# Altium schematic sheet SM-1301.SchDoc
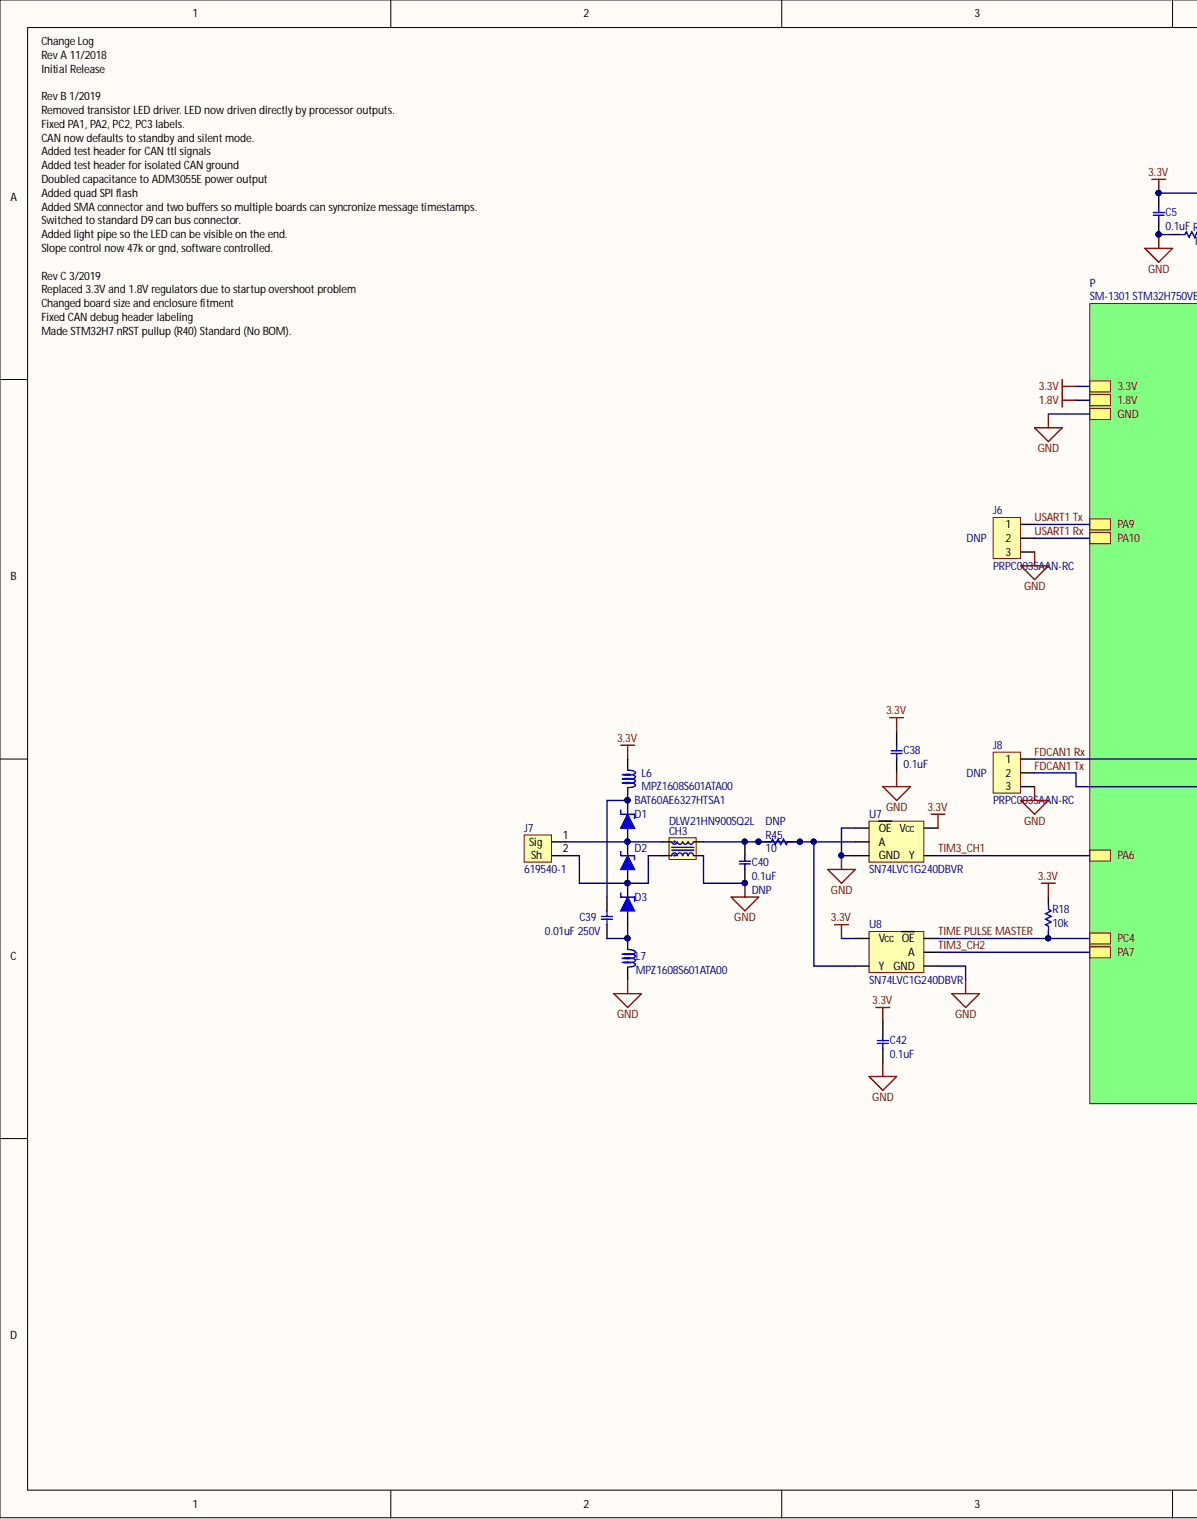
[diagram p0: SM-1301.SchDoc, left]
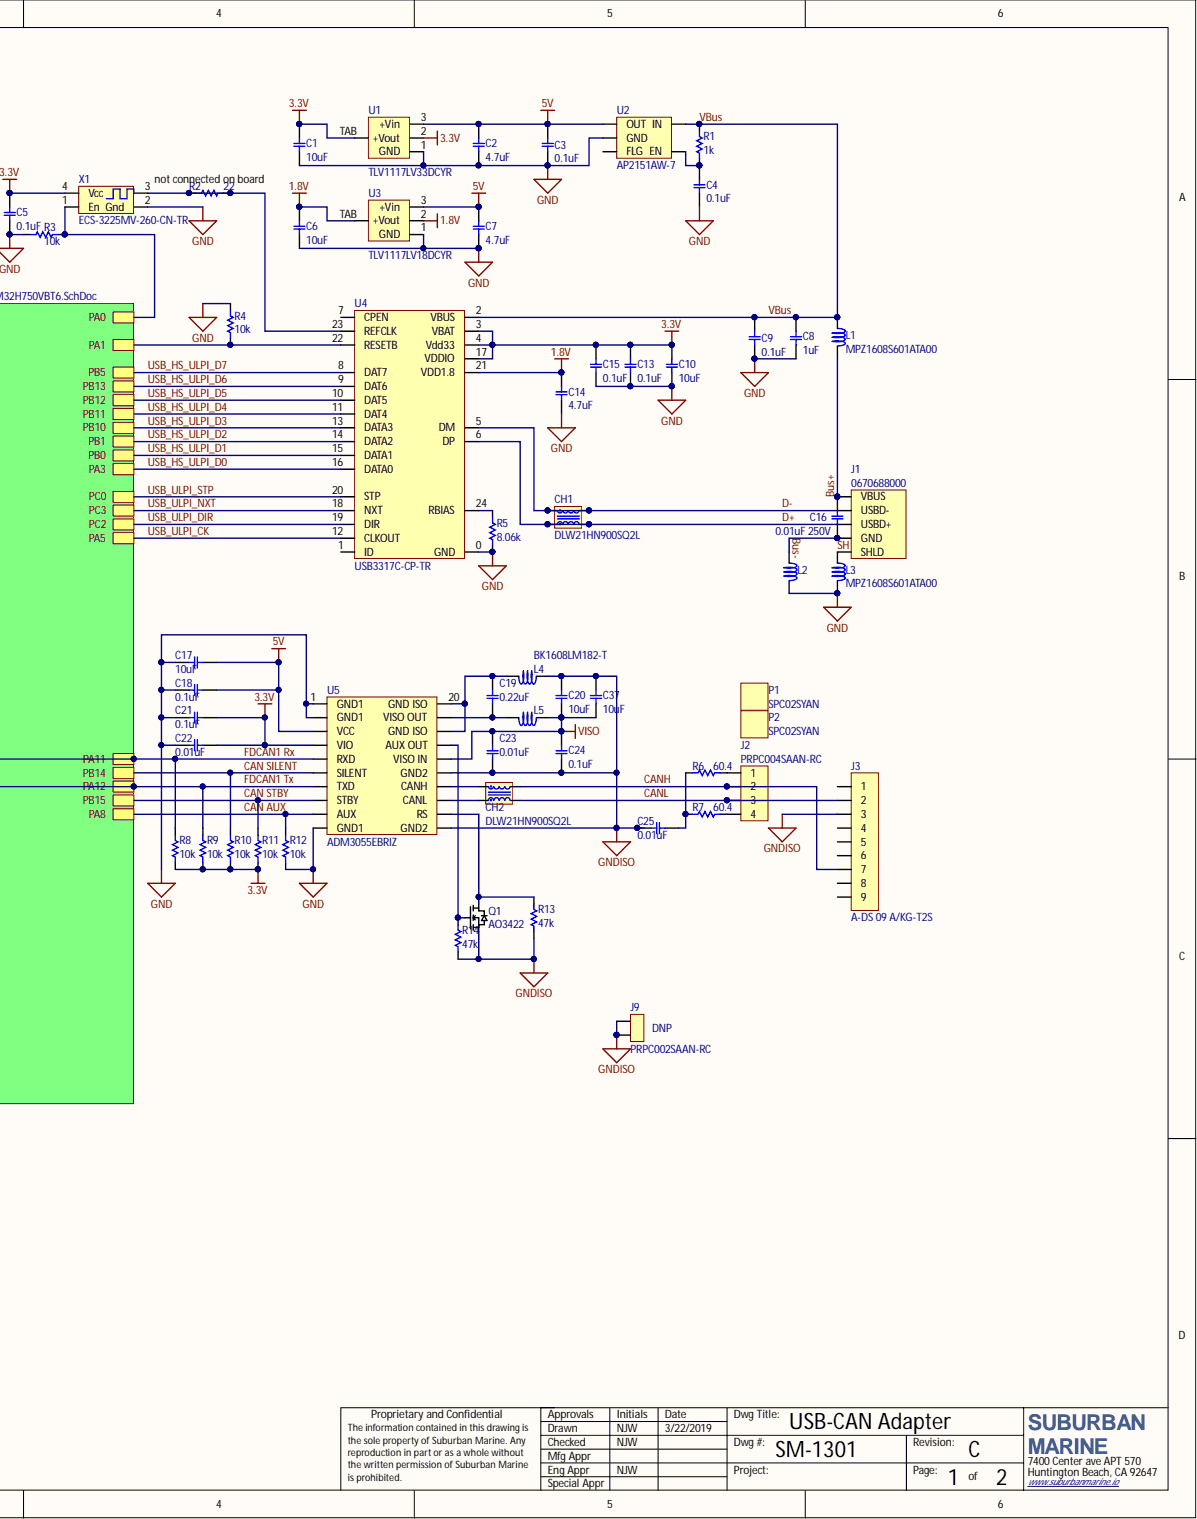
[diagram p1: SM-1301.SchDoc, right]

## Parts
C1  value 10uF  [0805]  (hidden comment: CL21A106KOQNNNG)
C2  value 4.7uF  [0805]  (hidden comment: CL21A475KOFNNNG)
C3  value 0.1uF  [0603]  (hidden comment: CL10B104KO8WPNC)
C4  value 0.1uF  [0603]  (hidden comment: CL10B104KO8WPNC)
C5  value 0.1uF  [0603]  (hidden comment: CL10B104KO8WPNC)
C6  value 10uF  [0805]  (hidden comment: CL21A106KOQNNNG)
C7  value 4.7uF  [0805]  (hidden comment: CL21A475KOFNNNG)
C8  value 1uF  [0603]  (hidden comment: CL10B105MO8NNWC)
C9  value 0.1uF  [0603]  (hidden comment: CL10B104KO8WPNC)
C10  value 10uF  [0805]  (hidden comment: CL21A106KOQNNNG)
C13  value 0.1uF  [0603]  (hidden comment: CL10B104KO8WPNC)
C14  value 4.7uF  [0805]  (hidden comment: CL21A475KOFNNNG)
C15  value 0.1uF  [0603]  (hidden comment: CL10B104KO8WPNC)
C16  value 0.01uF 250V  [0805]  (hidden comment: C0805C103KARACTU)
C17  value 10uF  [0805]  (hidden comment: CL21A106KOQNNNG)
C18  value 0.1uF  [0603]  (hidden comment: CL10B104KO8WPNC)
C19  value 0.22uF  [0805]  (hidden comment: CL21B224KOCNNNC)
C20  value 10uF  [0805]  (hidden comment: CL21A106KOQNNNG)
C21  value 0.1uF  [0603]  (hidden comment: CL10B104KO8WPNC)
C22  value 0.01uF  [0603]  (hidden comment: CL10B103KA8WPNC)
C23  value 0.01uF  [0603]  (hidden comment: CL10B103KA8WPNC)
C24  value 0.1uF  [0603]  (hidden comment: CL10B104KO8WPNC)
C25  value 0.01uF  [0603]  (hidden comment: CL10B103KA8WPNC)
C37  value 10uF  [0805]  (hidden comment: CL21A106KOQNNNG)
C38  value 0.1uF  [0603]  (hidden comment: CL10B104KO8WPNC)
C39  value 0.01uF 250V  [0805]  (hidden comment: C0805C103KARACTU)
C40  value 0.1uF  [0603]  (hidden comment: CL10B104KO8WPNC)  (no BOM)
C42  value 0.1uF  [0603]  (hidden comment: CL10B104KO8WPNC)
CH1  DLW21HN900SQ2L  [ACM2012]  (no BOM)
CH2  DLW21HN900SQ2L  [ACM2012]
CH3  DLW21HN900SQ2L  [ACM2012]  (no BOM)
D1  BAT60AE6327HTSA1  [SOD-323]
D2  BAT60AE6327HTSA1  [SOD-323]
D3  BAT60AE6327HTSA1  [SOD-323]
J1  0670688000  [0670688000]
J2  PRPC004SAAN-RC  [HDR1X4]
J3  A-DS 09 A/KG-T2S  [A-DS 09 A/KG-T2S]
J6  PRPC003SAAN-RC  [HDR1X3]  (no BOM)
J7  619540-1  [619540-1]
J8  PRPC003SAAN-RC  [HDR1X3]  (no BOM)
J9  PRPC002SAAN-RC  [HDR1X2]  (no BOM)
L1  MPZ1608S601ATA00  [0603]
L2  MPZ1608S601ATA00  [0603]
L3  MPZ1608S601ATA00  [0603]
L4  BK1608LM182-T  [0603]
L5  BK1608LM182-T  [0603]
L6  MPZ1608S601ATA00  [0603]
L7  MPZ1608S601ATA00  [0603]
P1  SPC02SYAN  [969102-0000-DA]
P2  SPC02SYAN  [969102-0000-DA]
Q1  AO3422  [SOT-23]
R1  value 1k  [0603]  (hidden comment: RC0603FR-071KL)
R2  value 22  [0603]  (hidden comment: ERJ-3EKF22R0V)  (no BOM)
R3  value 10k  [0603]  (hidden comment: ERJ-3EKF1002V)
R4  value 10k  [0603]  (hidden comment: ERJ-3EKF1002V)
R5  value 8.06k  [0603]  (hidden comment: ERJ-3EKF8061V)
R6  value 60.4  [0603]  (hidden comment: ERJ-3EKF60R4V)
R7  value 60.4  [0603]  (hidden comment: ERJ-3EKF60R4V)
R8  value 10k  [0603]  (hidden comment: ERJ-3EKF1002V)
R9  value 10k  [0603]  (hidden comment: ERJ-3EKF1002V)
R10  value 10k  [0603]  (hidden comment: ERJ-3EKF1002V)
R11  value 10k  [0603]  (hidden comment: ERJ-3EKF1002V)
R12  value 10k  [0603]  (hidden comment: ERJ-3EKF1002V)
R13  value 47k  [0603]  (hidden comment: RC0603FR-0747KL)
R14  value 47k  [0603]  (hidden comment: RC0603FR-0747KL)
R18  value 10k  [0603]  (hidden comment: ERJ-3EKF1002V)
R45  value 10  [0603]  (hidden comment: RC0603FR-0710RL)  (no BOM)
U1  TLV1117LV33DCYR  value 3.3V  [SOT-223]
U2  AP2151AW-7  [sot23-5]
U3  TLV1117LV18DCYR  value 1.8V  [SOT-223]
U4  USB3317C-CP-TR  [qfn-24]
U5  ADM3055EBRIZ  [SOIC-20w]
U7  SN74LVC1G240DBVR  [sot-23-5]
U8  SN74LVC1G240DBVR  [sot-23-5]
X1  ECS-3225MV-260-CN-TR  [3.2x2.5]

## Nets
1.8V: C6.2 C14.2 U3.2 U3.TAB(+Vout) U4.21(VDD1.8)
3.3V: C1.2 C5.1 C10.2 C13.1 C15.1 C21.1 C22.1 C38.2 C42.2 L6.2 R8.2 R9.2 R10.2 R11.2 R18.1 U1.2 U1.TAB(+Vout) U4.3(VBAT) U4.4(Vdd33) U4.17(VDDIO) U5.4(VIO) U7.5(Vcc) U8.5(Vcc) X1.4(Vcc)
5V: C2.2 C3.2 C7.2 C17.2 C18.2 U1.3(+Vin) U2.1(OUT) U3.3(+Vin) U5.3(VCC)
GND: C1.1 C2.1 C3.1 C4.2 C5.2 C6.1 C7.1 C8.1 C9.1 C10.1 C13.2 C14.1 C15.2 C17.1 C18.1 C21.2 C22.2 C38.1 C40.1 C42.1 CH3.3 J6.3 J8.3 L2.1 L3.1 L7.1 R3.2 R4.2 R5.1 R12.2 U1.1(GND) U2.2(GND) U3.1(GND) U4.0(GND) U5.1(GND1) U5.2(GND1) U5.10(GND1) U7.1(OE) U7.3(GND) U8.3(GND) X1.2(Gnd)
GNDISO: C20.2 C23.1 C24.1 C25.2 C37.2 J3.3 J9.1 J9.2 L4.2 Q1.3 R13.2 R14.2 U5.11(GND2) U5.15(GND2)
VISO: C20.1 C23.2 C24.2 C37.1 L5.2 U5.16(VISO_IN)
Bus+: C16.2 J1.1(VBUS) L1.1
Bus-: C16.1 J1.4(GND) L2.2
CAN AUX: R12.1 U5.9(AUX)
CAN SILENT: R10.1 U5.6(SILENT)
CAN STBY: R11.1 U5.8(STBY)
CANH: CH2.4 J2.2 J3.7
CANL: CH2.3 J2.3 J3.2
D+: CH1.2 CH1.3 J1.3(USBD+) U4.6(DP)
D-: CH1.1 CH1.4 J1.2(USBD-) U4.5(DM)
FDCAN1 Rx: J8.1 R8.1 U5.5(RXD)
FDCAN1 Tx: J8.2 R9.1 U5.7(TXD)
SH: J1.0(SHLD) L3.2
TIM3_CH1: U7.4(Y)
TIM3_CH2: U8.2(A)
TIME PULSE MASTER: R18.2 U8.1(OE)
USART1 Rx: J6.2
USART1 Tx: J6.1
USB_HS_ULPI_D0: U4.16(DATA0)
USB_HS_ULPI_D1: U4.15(DATA1)
USB_HS_ULPI_D2: U4.14(DATA2)
USB_HS_ULPI_D3: U4.13(DATA3)
USB_HS_ULPI_D4: U4.11(DAT4)
USB_HS_ULPI_D5: U4.10(DAT5)
USB_HS_ULPI_D6: U4.9(DAT6)
USB_HS_ULPI_D7: U4.8(DAT7)
USB_ULPI_CK: U4.12(CLKOUT)
USB_ULPI_DIR: U4.19(DIR)
USB_ULPI_NXT: U4.18(NXT)
USB_ULPI_STP: U4.20(STP)
VBus: C8.2 C9.2 L1.2 R1.2 U2.5(IN) U4.2(VBUS)
NetC4_1: C4.1 R1.1 U2.4(EN)
NetC19_1: C19.1 L5.1 U5.19(VISO_OUT)
NetC19_2: C19.2 L4.1 U5.18(GND_ISO) U5.20(GND_ISO)
NetC25_1: C25.1 R6.1 R7.1
NetC39_1: C39.1 D3.1 L7.2
NetC39_2: C39.2 D1.2 L6.1
NetC40_2: C40.2 CH3.4 R45.1 R45.2 U7.2(A) U8.4(Y)
NetCH2_1: CH2.1 U5.14(CANH)
NetCH2_2: CH2.2 U5.13(CANL)
NetCH3_1: CH3.1 D1.1 D2.2 J7.1
NetCH3_2: CH3.2 D2.1 D3.2 J7.2
NetJ2_1: J2.1 R6.2
NetJ2_4: J2.4 R7.2
NetJ3_1: J3.1
NetJ3_4: J3.4
NetJ3_5: J3.5
NetJ3_6: J3.6
NetJ3_8: J3.8
NetJ3_9: J3.9
NetQ1_1: Q1.1 R14.1 U5.17(AUX_OUT)
NetQ1_2: Q1.2 R13.1 U5.12(RS)
NetR2_1: R2.1 R2.2 U4.23(REFCLK) X1.3
NetR3_1: R3.1 X1.1(En)
NetR4_1: R4.1 U4.22(RESETB)
NetR5_2: R5.2 U4.24(RBIAS)
NetU2_3: U2.3(FLG)
NetU4_1: U4.1(ID)
NetU4_7: U4.7(CPEN)

## Library data of the parts
C1 C6 C10 C17 C20 C37 = 10uF 16V 0805: CAP CER 10UF 16V X5R 0805; Manufacturer: Samsung Electro-Mechanics; Installation: SMD/SMT; Lead: 0; Vendor: Digikey; Vendor #: 1276-6455-1-ND; Component Kind: Standard; Library Name: Capacitor.SchLib; Manufacturer #: CL21A106KOQNNNG; Price: .26; Temperature: -55 to 85 C
C2 C7 C14 = 4.7uF 25V 0805: CAP CER 4.7UF 16V X5R 0805; Manufacturer: Samsung Electro-Mechanics; Installation: SMD/SMT; Lead: 0; Tolerance: ±10%; Vendor: Digikey; Vendor #: 1276-6463-1-ND; Component Kind: Standard; Library Name: Capacitor.SchLib; Manufacturer #: CL21A475KOFNNNG; Price: 0.2; Temperature: -55 to 125 C
C3-C5 C9 C13 C15 C18 C21 C24 C38 C40 C42 = 0.1uF 16V 0603: CAP CER 0.1UF 16V X7R 0603; Manufacturer: Samsung Electro-Mechanics; Installation: SMD/SMT; Lead: 0; Tolerance: 10%; Vendor: Digikey; Vendor #: 1276-6582-1-ND; Library Name: Capacitor.SchLib; Manufacturer #: CL10B104KO8WPNC; Price: 0.1; Temperature: -55 to 125 C
  C3-C5 C9 C13 C15 C18 C21 C24 C38 C42: Component Kind: Standard
C8 = 1uF 16V 0603: CAP CER 1UF 16V X7R 0603; Manufacturer: Samsung Electro-Mechanics; Installation: SMD/SMT; Lead: 0; Vendor: Digikey; Vendor #: 1276-6524-1-ND; Component Kind: Standard; Library Name: Capacitor.SchLib; Manufacturer #: CL10B105MO8NNWC; Price: 0.10; Temperature: -55 to 125 C; Tolerance: 16V
C16 C39 = 0.01uF 250V 0805: CAP CER 10000PF 250V X7R 0805; Manufacturer: Kemet; Installation: SMD/SMT; Lead: 0; Tolerance: 250v 10%; Vendor: Digikey; Vendor #: 399-4669-1-ND; Component Kind: Standard; Library Name: Capacitor.SchLib; Manufacturer #: C0805C103KARACTU; Price: 0.23; Temperature: -55 to 125 C
C19 = 0.22uF 16V 0805: CAP CER 0.22UF 16V X7R 0805; Manufacturer: Samsung Electro-Mechanics; Installation: SMD/SMT; Lead: 0; Tolerance: 10%; Vendor: Digikey; Vendor #: 1276-1284-1-ND; Component Kind: Standard; Library Name: Capacitor.SchLib; Manufacturer #: CL21B224KOCNNNC; Price: .13; Temperature: -55 to 125 C
C22 C23 C25 = 0.01uF 25V 0603: CAP CER 10000PF 25V X7R 0603; Manufacturer: Samsung Electro-Mechanics; Installation: SMD/SMT; Lead: 0; Tolerance: 10%; Vendor: Digikey; Vendor #: 1276-6531-1-ND; Component Kind: Standard; Library Name: Capacitor.SchLib; Manufacturer #: CL10B103KA8WPNC; Price: .13; Temperature: -55 to 125 C
CH1-CH3 = DLW21HN900SQ2L: CMC 330MA 2LN 90 OHM SMD; Manufacturer: Murata Electronics North America; Installation: SMD/SMT; Lead: 0; Value: 90Ω@100MHz; Vendor: Digikey; Vendor #: 490-1064-1-ND; Library Name: Inductor.SchLib; Manufacturer #: DLW21HN900SQ2L; Price: 0.53; Temperature: -40 to 85 C
  CH2: Component Kind: Standard
D1-D3 = BAT60AE6327HTSA1: DIODE SCHOTTKY 10V 3A SOD-323; Manufacturer: Infineon Technologies; Installation: SMD/SMT; Lead: 0; Vendor: Digikey; Vendor #: BAT60AE6327HTSA1CT-ND; Component Kind: Standard; Library Name: Diode.SchLib; Manufacturer #: BAT60AE6327HTSA1; Price: 0.5; Temperature: -55 to 125 C
J1 = 0670688000: CONN USB RT ANG RECPT TYPE B BLK; Manufacturer: Molex, LLC; Installation: SMD/SMT; Lead: 0; Vendor: Digikey; Vendor #: WM17113-ND; Component Kind: Standard; Library Name: Connectors.SchLib; Manufacturer #: 0670688000; Price: 1.53; Temperature: 0 to 50 C
J2 = Header 1x4: CONN HEADER 4 POS .100" SGL GOLD; Manufacturer: Sullins Connector Solutions; Installation: Thru-hole; Lead: 0; Value: 4 pos; Vendor: Digikey; Vendor #: S1011EC-04-ND; Component Kind: Standard; Library Name: Headers.SchLib; Manufacturer #: PRPC004SAAN-RC; PCB3D: HDR1X4; Price: 0.74; Temperature: -40 to 105 C
J3 = A-DS 09 A/KG-T2S: CONN D-SUB PLUG 9POS R/A SOLDER; Manufacturer: Assmann WSW Components; Installation: Thru-hole; Lead: 0; Vendor: Digikey; Vendor #: AE10968-ND; Component Kind: Standard; Library Name: Connectors.SchLib; Manufacturer #: A-DS 09 A/KG-T2S; Price: 0.77; Temperature: -55 to 105 C; Value: 9 pos
J6 J8 = Header 1x3: CONN HEADER .100" SNGL STR 3POS; Manufacturer: Sullins Connector Solutions; Installation: Thru-hole; Lead: 0; Vendor: Digikey; Vendor #: S1011EC-03-ND; Library Name: Headers.SchLib; Manufacturer #: PRPC003SAAN-RC; Price: 0.12; Temperature: -40 to 105 C; Value: 3 pos
J7 = 619540-1: CONN SMA JACK R/A 50 OHM PCB; Manufacturer: TE Connectivity AMP Connectors; Manufacturer #: A120734-ND; Installation: Thru-hole; Lead: 0; Vendor: Digikey; Component Kind: Standard; Library Name: Connectors.SchLib; Price: 3.67; Temperature: -65 to 85 C; Vendor #: A120734-ND
J9 = Header 1x2: CONN HEADER .100" SNGL STR 2POS; Manufacturer: Sullins Connector Solutions; Installation: Thru-hole; Lead: 0; Vendor: Digikey; Vendor #: S1011EC-02-ND; Library Name: Headers.SchLib; Manufacturer #: PRPC002SAAN-RC; Price: 0.08; Temperature: -40 to 105 C; Value: 2 pos
L1-L3 L6 L7 = MPZ1608S601ATA00: FERRITE BEAD 600 OHM 0603 1LN; Manufacturer: TDK Corporation; Installation: SMD/SMT; Lead: 0; Tolerance: 20%; Value: 600Ohm@100MHz; Vendor: Digikey; Vendor #: 445-2205-1-ND; Component Kind: Standard; Library Name: Inductor.SchLib; Manufacturer #: MPZ1608S601ATA00; Price: 2.12; Temperature: -40 to 125 C
L4 L5 = BK1608LM182-T: FERRITE BEAD 1.8 KOHM 0603 1LN; Manufacturer: Taiyo Yuden; Installation: SMD/SMT; Lead: 0; Tolerance: 20%; Value: 1.8kOhm@100MHz; Vendor: Digikey; Vendor #: 587-1892-1-ND; Component Kind: Standard; Library Name: Inductor.SchLib; Manufacturer #: BK1608LM182-T; Price: 0.11; Temperature: -40 to 125 C
P1 P2 = SPC02SYAN: CONN JUMPER SHORTING GOLD FLASH; Manufacturer: Sullins Connector Solutions; Installation: Push-in; Lead: 0; Vendor: Digikey; Vendor #: S9001-ND; Component Kind: Standard; Library Name: Misc Components.SchLib; Manufacturer #: SPC02SYAN; Price: 0.10; Temperature: -40 to 85 C
Q1 = AO3422: MOSFET N-CH 55V 2.1A SOT23; Manufacturer: Alpha & Omega Semiconductor Inc.; Installation: SMD/SMT; Lead: 0; Vendor: Digikey; Vendor #: 785-1015-1-ND; Component Kind: Standard; Library Name: Transistor.SchLib; Manufacturer #: AO3422; Price: 0.61; Temperature: -55 to 150 C
R1 = 1k 0603: RES 1.00K OHM 1/10W 1% 0603 SMD; Manufacturer: Yageo; Installation: SMD/SMT; Lead: 0; Vendor: Digikey; Vendor #: 311-1.00KHRCT-ND; Component Kind: Standard; Library Name: Resistor.SchLib; Manufacturer #: RC0603FR-071KL; Price: 0.067; Simulation: Resistor 1k; Temperature: -55 to 155 C; Tolerance: 1%
R2 = 22 0603: RES SMD 22 OHM 1% 1/10W 0603; Manufacturer: Panasonic Electronic Components; Installation: SMD/SMT; Lead: 0; Vendor: Digikey; Vendor #: P22.0HCT-ND; Library Name: Resistor.SchLib; Manufacturer #: ERJ-3EKF22R0V; Price: 0.1; Simulation: Resistor 10k; Temperature: -55 to 155 C; Tolerance: 1%
R3 R4 R8-R12 R18 = 10k 0603: RES SMD 10K OHM 1% 1/10W 0603; Manufacturer: Panasonic Electronic Components; Installation: SMD/SMT; Lead: 0; Vendor: Digikey; Vendor #: P10.0KHCT-ND; Component Kind: Standard; Library Name: Resistor.SchLib; Manufacturer #: ERJ-3EKF1002V; Price: 0.1; Simulation: Resistor 10k; Temperature: -55 to 155 C; Tolerance: 1%
R5 = 8.06k 0603: RES SMD 8.06K OHM 1% 1/10W 0603; Manufacturer: Panasonic Electronic Components; Installation: SMD/SMT; Lead: 0; Vendor: Digikey; Vendor #: P8.06KHCT-ND; Component Kind: Standard; Library Name: Resistor.SchLib; Manufacturer #: ERJ-3EKF8061V; Price: 0.1; Temperature: -55 to 155 C; Tolerance: 1%
R6 R7 = 60.4 0603: RES SMD 60.4 OHM 1% 1/10W 0603; Manufacturer: Panasonic Electronic Components; Installation: SMD/SMT; Lead: 0; Vendor: Digikey; Vendor #: P60.4HCT-ND; Component Kind: Standard; Library Name: Resistor.SchLib; Manufacturer #: ERJ-3EKF60R4V; PCB3D: Resistor_0603; Price: 0.067; Temperature: -55 to 155 C; Tolerance: 1%
R13 R14 = 47k 0603: RES SMD 47K OHM 1% 1/10W 0603; Manufacturer: Yageo; Installation: SMD/SMT; Lead: 0; Vendor: Digikey; Vendor #: 311-47.0KHRCT-ND; Component Kind: Standard; Library Name: Resistor.SchLib; Manufacturer #: RC0603FR-0747KL; PCB3D: Resistor_0603; Price: 0.1; Temperature: -50 to 155 C; Tolerance: 1%
R45 = 10 0603: RES 10.0 OHM 1/10W 1% 0603 SMD; Manufacturer: Yageo; Installation: SMD/SMT; Lead: 0; Vendor: Digikey; Vendor #: 311-10.0HRCT-ND; Library Name: Resistor.SchLib; Manufacturer #: RC0603FR-0710RL; Price: .067; Temperature: -55 to 155 C; Tolerance: 1%
U1 = TLV1117LV33DCYR: IC REG LINEAR 3.3V 1A SOT223-4; Manufacturer: Texas Instruments; Installation: SMD/SMT; Lead: 0; Vendor: Digikey; Vendor #: 296-28778-1-ND; Component Kind: Standard; Library Name: Power Supply.SchLib; Manufacturer #: TLV1117LV33DCYR; Price: 0.69; Temperature: -40 to 125 C
U2 = AP2151AW-7: IC USB SW HISIDE SGL 0.5A SOT25; Manufacturer: Diodes Incorporated; Installation: SMD/SMT; Lead: 0; Vendor: Digikey; Vendor #: AP2151AW-7DICT-ND; Component Kind: Standard; Library Name: Digital IC.SchLib; Manufacturer #: AP2151AW-7; Price: 0.5; Temperature: -40 to 85 C
U3 = TLV1117LV18DCYR: IC REG LINEAR 1.8V 1A SOT223-4; Manufacturer: Texas Instruments; Installation: SMD/SMT; Lead: 0; Vendor: Digikey; Vendor #: 296-28777-1-ND; Component Kind: Standard; Library Name: Power Supply.SchLib; Manufacturer #: TLV1117LV18DCYR; Price: 0.69; Temperature: -40 to 125 C
U4 = USB3317C-CP-TR: IC TXRX USB 2.0 FLEXPWR 24QFN; Manufacturer: Microchip Technology; Installation: SMD/SMT; Lead: 0; Value: USB 2.0 HS ULPI; Vendor: Digikey; Vendor #: 638-1092-1-ND; Component Kind: Standard; Library Name: Digital IC.SchLib; Manufacturer #: USB3317C-CP-TR; Price: 1.28; Temperature: -40 to 85 C
U5 = ADM3055EBRIZ: 5KV ISOPOWER ISOLATED CAN-FD TRA; Manufacturer: Analog Devices Inc; Installation: SMD/SMT; Lead: 0; Value: 12Mbit CAN FD; Vendor: Digikey; Vendor #: ADM3055EBRIZ-ND; Component Kind: Standard; Library Name: Digital IC.SchLib; Manufacturer #: ADM3055EBRIZ; Price: 12.79; Temperature: -40 to 105 C
U7 U8 = SN74LVC1G240DBVR: IC BUFFER INVERT 5.5V SOT23-5; Manufacturer: Texas Instruments; Installation: SMD/SMT; Lead: 0; Value: 3S Buffer, NI; Vendor: Digikey; Vendor #: 296-11609-1-ND; Component Kind: Standard; Library Name: Logic IC.SchLib; Manufacturer #: SN74LVC1G240DBVR; Price: 0.36; Temperature: -40 to 125 C
X1 = ECS-3225MV-260-CN-TR: XTAL OSC XO 26.0000MHZ HCMOS SMD; Manufacturer: ECS Inc.; Installation: SMD/SMT; Lead: 0; Tolerance: ±25ppm; Vendor: Digikey; Vendor #: XC2886CT-ND; Component Kind: Standard; Library Name: Oscillators.SchLib; Manufacturer #: ECS-3225MV-260-CN-TR; Price: 0.97; Temperature: -40 to 85 C
